# Altium schematic sheet 03_internal_sensors.SchDoc(03_internal_sensors)
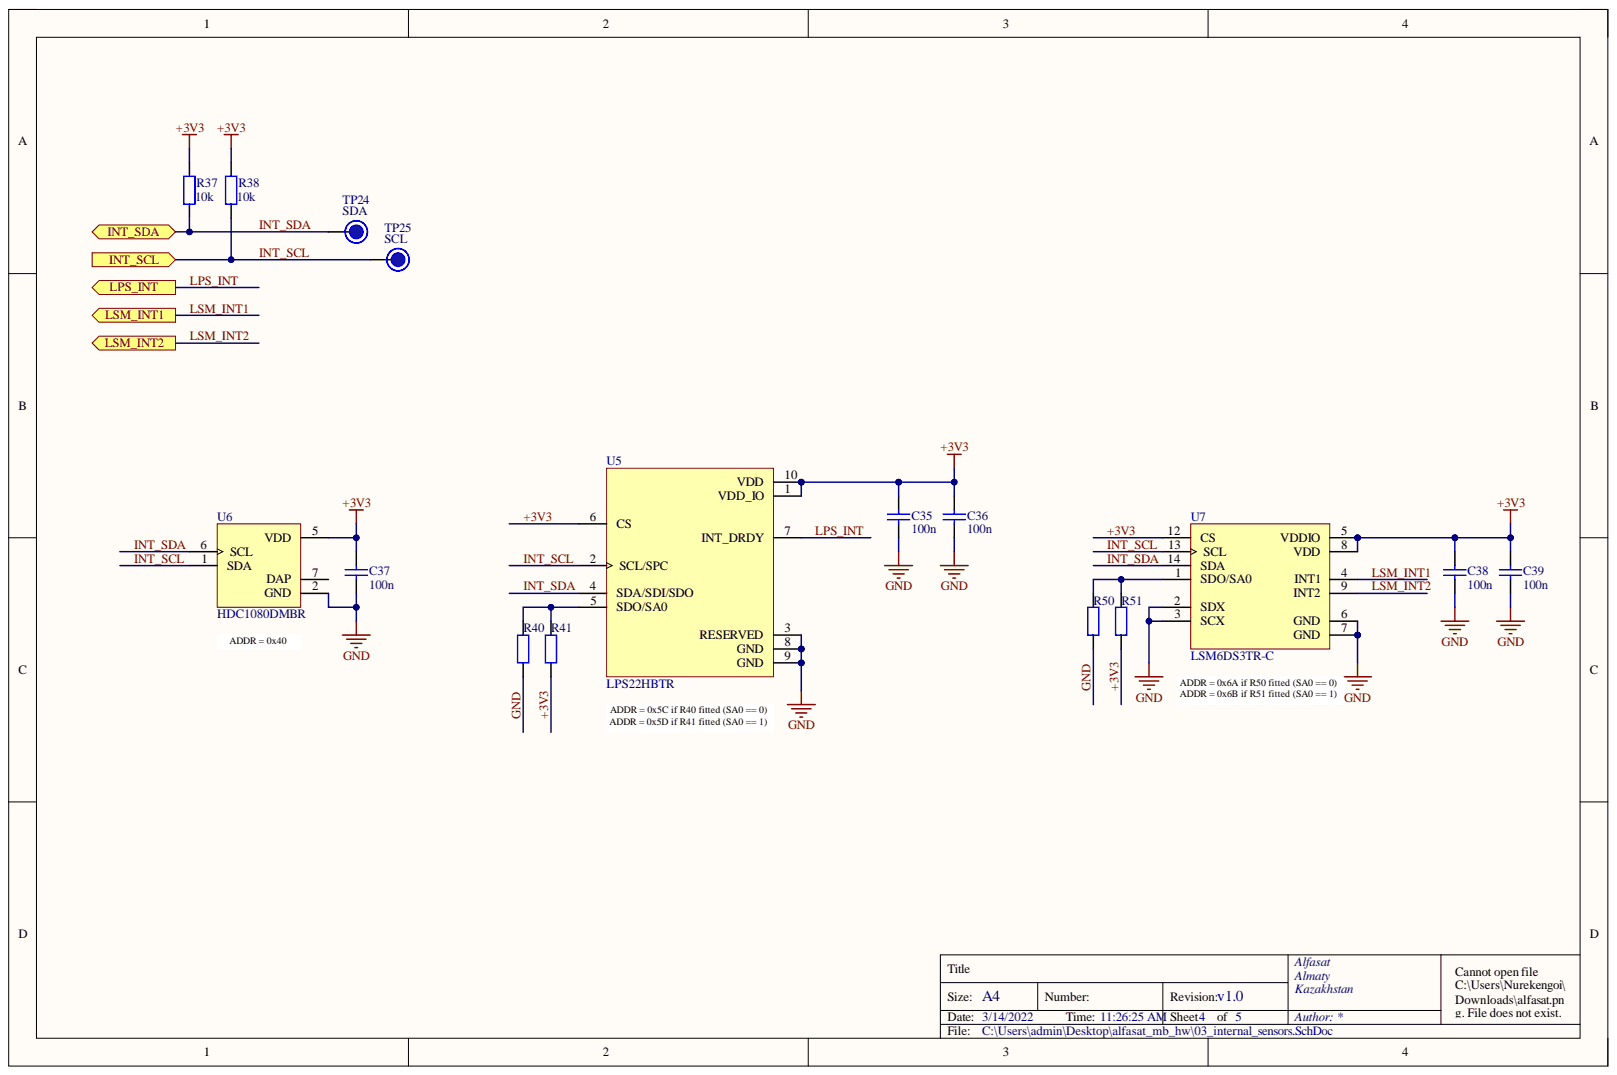
[diagram p0: 03_internal_sensors.SchDoc]

## Parts
C35  100n  [C0603_IPC_MD]
C36  100n  [C0603_IPC_MD]
C37  100n  [C0603_IPC_MD]
C38  100n  [C0603_IPC_MD]
C39  100n  [C0603_IPC_MD]
R37  10k  [R0603_IPC_MD]
R38  10k  [R0603_IPC_MD]
R40  0R  [R0603_IPC_MD]  (value not drawn)
R41  0R  [R0603_IPC_MD]  (value not drawn)
R50  0R  [R0603_IPC_MD]  (value not drawn)
R51  0R  [R0603_IPC_MD]  (value not drawn)
TP24  SDA  [TestPoint_1M0]  (no BOM)
TP25  SCL  [TestPoint_1M0]  (no BOM)
U5  LPS22HBTR  [LPS22HB]
U6  HDC1080DMBR  [HDC1080]
U7  LSM6DS3TR-C  [LSM6DS]

## Ports
INT_SCL, INT_SDA, LPS_INT, LSM_INT1, LSM_INT2

## Nets
+3V3: C35.2 C36.2 C37.2 C38.2 C39.2 R37.2 R38.2 R41.1 R51.1 U5.1(VDD_IO) U5.6(CS) U5.10(VDD) U6.5(VDD) U7.5(VDDIO) U7.8(VDD) U7.12(CS)
GND: C35.1 C36.1 C37.1 C38.1 C39.1 R40.1 R50.1 U5.3(RESERVED) U5.8(GND) U5.9(GND) U6.2(GND) U7.2(SDX) U7.3(SCX) U7.6(GND) U7.7(GND)
INT_SCL: R38.1 TP25.0 U5.2(SCL/SPC) U6.1(SDA) U7.13(SCL) ; port INT_SCL
INT_SDA: R37.1 TP24.0 U5.4(SDA/SDI/SDO) U6.6(SCL) U7.14(SDA) ; port INT_SDA
LPS_INT: U5.7(INT_DRDY) ; port LPS_INT
LSM_INT1: U7.4(INT1) ; port LSM_INT1
LSM_INT2: U7.9(INT2) ; port LSM_INT2
NetR40_2: R40.2 R41.2 U5.5(SDO/SA0)
NetR50_2: R50.2 R51.2 U7.1(SDO/SA0)

Not connected: U6 7(DAP)

## Library data of the parts
C35-C39 = CL10B104KO8NNNC: CAP CER 0.1UF 16V X7R 0603; Manufacturer: Samsung Electro-Mechanics; Component Kind: Standard; Dielectric: X7R; Manufacturer Part Number: CL10B104KO8NNNC; Supplier 1: Digi-Key; Supplier Part Number 1: 1276-1005-1-ND; Value: 100n; Voltage: 16V; Library Name: alfasat_parts_lib.IntLib
R37 R38 = CR0603-JW-10k: RES 10K OHM 1% 1/10W 0603; Manufacturer: Stackpole Electronics Inc; Manufacturer Part Number: RMCF0603FT10K0; Component Kind: Standard; Supplier 1: Digi-Key; Supplier Part Number 1: RMCF0603FT10K0CT-ND; Tolarance: ±1%; Value: 10k; Library Name: alfasat_parts_lib.IntLib
R40 R41 R50 R51 = CR0603-J/-000ELF: RES SMD 0 OHM JUMPER 1/10W 0603; Manufacturer: Bourns Inc.; Tolarance: 1%; Component Kind: Standard; Manufacturer Part Number: CR0603-J/-000ELF; Supplier 1: Digi-Key; Supplier Part Number 1: CR0603-J/-000ELFCT-ND; Value: 0R; Library Name: alfasat_parts_lib.IntLib
TP24 TP25 = TP: Test Point; Library Name: alfasat_parts_lib.IntLib
U5 = LPS22HBTR: MEMS NANO PRESSURE SENSOR: 260-1; Manufacturer: STMicroelectronics; Component Kind: Standard; Manufacturer Part Number: LPS22HBTR; Supplier 1: LCSC; Supplier Part Number 1: C94049; Library Name: alfasat_parts_lib.IntLib
U6 = HDC1080DMBT: SENSOR HUMI/TEMP 3.3V I2C 4% SMD; Manufacturer: Texas Instruments; Component Kind: Standard; Manufacturer Part Number: HDC1080DMBR; Supplier 1: LCSC; Supplier Part Number 1: C82227; Library Name: alfasat_parts_lib.IntLib
U7 = LSM6DS3TR-C: IMU ACCEL/GYRO I2C/SPI 14VFLGA; Manufacturer: STMicroelectronics; Component Kind: Standard; Manufacturer Part Number: LSM6DS3TR-C; Supplier 1: LCSC; Supplier Part Number 1: C967633; Library Name: alfasat_parts_lib.IntLib
